# Altium schematic sheet IoT_Greenhouse V1.0 A - Outputs.SchDoc("IoT_Greenhouse V1.0 A - Outputs")
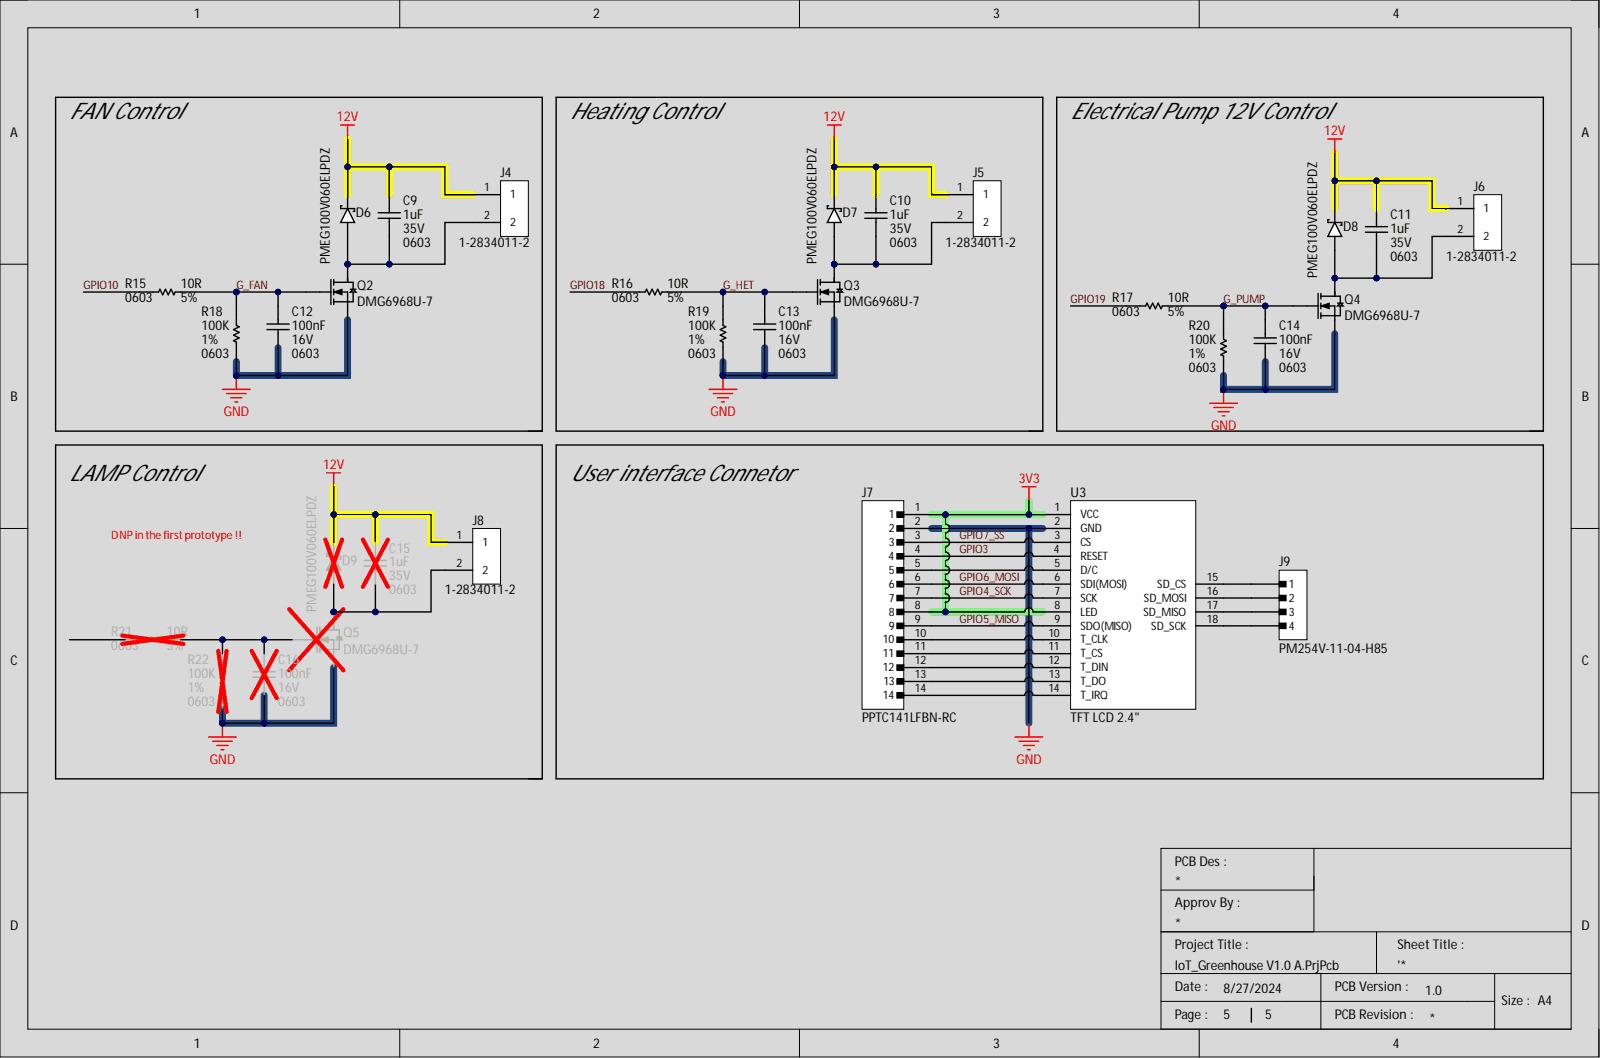
[diagram p0: IoT_Greenhouse V1.0 A - Outputs.SchDoc]

## Parts
C9  Capacitance: 1uF  Case Code (Imperial): 0603  Voltage Rating: 35V  [CAP_0603_CAPC1608X08N]  (hidden comment: GMK107BJ105KA-T)
C10  Capacitance: 1uF  Case Code (Imperial): 0603  Voltage Rating: 35V  [CAP_0603_CAPC1608X08N]  (hidden comment: GMK107BJ105KA-T)
C11  Capacitance: 1uF  Case Code (Imperial): 0603  Voltage Rating: 35V  [CAP_0603_CAPC1608X08N]  (hidden comment: GMK107BJ105KA-T)
C12  Capacitance: 100nF  Case Code (Imperial): 0603  Voltage: 16V  [CAP_0603_CAPC1608X08N]  (hidden comment: CL10B104KO8NNNC)
C13  Capacitance: 100nF  Case Code (Imperial): 0603  Voltage: 16V  [CAP_0603_CAPC1608X08N]  (hidden comment: CL10B104KO8NNNC)
C14  Capacitance: 100nF  Case Code (Imperial): 0603  Voltage: 16V  [CAP_0603_CAPC1608X08N]  (hidden comment: CL10B104KO8NNNC)
C15  Capacitance: 1uF  Case Code (Imperial): 0603  Voltage Rating: 35V  [CAP_0603_CAPC1608X08N]  (hidden comment: GMK107BJ105KA-T)
C16  Capacitance: 100nF  Case Code (Imperial): 0603  Voltage: 16V  [CAP_0603_CAPC1608X08N]  (hidden comment: CL10B104KO8NNNC)
D6  PMEG100V060ELPDZ  [DIO_SCKTY_PMEG060V100EPDAZ]
D7  PMEG100V060ELPDZ  [DIO_SCKTY_PMEG060V100EPDAZ]
D8  PMEG100V060ELPDZ  [DIO_SCKTY_PMEG060V100EPDAZ]
D9  PMEG100V060ELPDZ  [DIO_SCKTY_PMEG060V100EPDAZ]
J4  1-2834011-2  [CONN_TBLOCK_128340112]
J5  1-2834011-2  [CONN_TBLOCK_128340112]
J6  1-2834011-2  [CONN_TBLOCK_128340112]
J7  PPTC141LFBN-RC  [CONN_HEADER_RHDR14W80P0X254_1X14_3606X254X850P]
J8  1-2834011-2  [CONN_TBLOCK_128340112]
J9  PM254V-11-04-H85  [CONN_HEADER_FEM_4P]
Q2  DMG6968U-7  [SOT_23_3_MMBT2907A-13]
Q3  DMG6968U-7  [SOT_23_3_MMBT2907A-13]
Q4  DMG6968U-7  [SOT_23_3_MMBT2907A-13]
Q5  DMG6968U-7  [SOT_23_3_MMBT2907A-13]
R15  Case Code (Imperial): 0603  Resistance: 10R  Resistance Tolerance: 5%  [RES_0603_RESC1608X04N]  (hidden comment: RC0603JR-0710RL)
R16  Case Code (Imperial): 0603  Resistance: 10R  Resistance Tolerance: 5%  [RES_0603_RESC1608X04N]  (hidden comment: RC0603JR-0710RL)
R17  Case Code (Imperial): 0603  Resistance: 10R  Resistance Tolerance: 5%  [RES_0603_RESC1608X04N]  (hidden comment: RC0603JR-0710RL)
R18  Case Code (Imperial): 0603  Resistance: 100K  Resistance Tolerance: 1%  [RES_0603_RESC1608X04N]  (hidden comment: RC0603FR-07100KL)
R19  Case Code (Imperial): 0603  Resistance: 100K  Resistance Tolerance: 1%  [RES_0603_RESC1608X04N]  (hidden comment: RC0603FR-07100KL)
R20  Case Code (Imperial): 0603  Resistance: 100K  Resistance Tolerance: 1%  [RES_0603_RESC1608X04N]  (hidden comment: RC0603FR-07100KL)
R21  Case Code (Imperial): 0603  Resistance: 10R  Resistance Tolerance: 5%  [RES_0603_RESC1608X04N]  (hidden comment: RC0603JR-0710RL)
R22  Case Code (Imperial): 0603  Resistance: 100K  Resistance Tolerance: 1%  [RES_0603_RESC1608X04N]  (hidden comment: RC0603FR-07100KL)

## Nets
3V3: J7.1 J7.8
12V: C9.2 C10.2 C11.2 C15.2 D6.K D7.K D8.K D9.K J4.1 J5.1 J6.1 J8.1
GND: C12.1 C13.1 C14.1 C16.1 J7.2 Q2.2 Q3.2 Q4.2 Q5.2 R18.1 R19.1 R20.1 R22.1
G_FAN: C12.2 Q2.1 R15.2 R18.2
G_HET: C13.2 Q3.1 R16.2 R19.2
G_PUMP: C14.2 Q4.1 R17.2 R20.2
GPIO3: J7.4
GPIO4_SCK: J7.7
GPIO5_MISO: J7.9
GPIO6_MOSI: J7.6
GPIO7_SS: J7.3
GPIO10: R15.1
GPIO18: R16.1
GPIO19: R17.1
NetC9_1: C9.1 D6.A J4.2 Q2.3
NetC10_1: C10.1 D7.A J5.2 Q3.3
NetC11_1: C11.1 D8.A J6.2 Q4.3
NetC15_1: C15.1 D9.A J8.2 Q5.3
NetC16_2: C16.2 Q5.1 R21.2 R22.2

Not connected: J7 5 10 11 12 13 14; J9 1 2 3 4; R21 1

## Library data of the parts
C9-C11 C15 = CAP_1uF_35V_X5R_0603: Multilayer Ceramic Capacitors (High dielectric type), 35V, 1 uF, ± 10 %, 0603, X5R, RoHS; Manufacturer: Taiyo Yuden; Dielectric Material: 4241ConductorMaterial; Tolerance: 10%; Case Code (Metric): 1608; Case/Package: 0603; Category: Ceramic Capacitors; Component Kind: Standard; ComponentLink1Description: https://datasheet.ciiva.com/134/gmk107bj105ka-t-ss-134746.pdf?src-supplier=Digikey; ComponentLink1URL: https://datasheet.ciiva.com/134/gmk107bj105ka-t-ss-134746.pdf?src-supplier=Digikey; ComponentLink2Description: https://datasheet.ciiva.com/30065/198gmk107bj105ka-t-30065053.pdf?src-supplier=Onlinecomponents.com; ComponentLink2URL: https://datasheet.ciiva.com/30065/198gmk107bj105ka-t-30065053.pdf?src-supplier=Onlinecomponents.com; ComponentLink3Description: https://datasheet.ciiva.com/11463/gmk107bj105ka-t-ss-11463824.pdf?src-supplier=Avnet; ComponentLink3URL: https://datasheet.ciiva.com/11463/gmk107bj105ka-t-ss-11463824.pdf?src-supplier=Avnet; ComponentLink4Description: https://datasheet.ciiva.com/15920/1014gmk107bj105ka-t-15920678.pdf?src-supplier=Onlinecomponents.com; ComponentLink4URL: https://datasheet.ciiva.com/15920/1014gmk107bj105ka-t-15920678.pdf?src-supplier=Onlinecomponents.com; ComponentLink5Description: https://datasheet.ciiva.com/26871/getdatasheetpartid-637436-26871549.pdf?src-supplier=Verical; ComponentLink5URL: https://datasheet.ciiva.com/26871/getdatasheetpartid-637436-26871549.pdf?src-supplier=Verical; ComponentLink6Description: https://datasheet.ciiva.com/30181/9gmk107bj105ka-t-30181659.pdf?src-supplier=Onlinecomponents.com; ComponentLink6URL: https://datasheet.ciiva.com/30181/9gmk107bj105ka-t-30181659.pdf?src-supplier=Onlinecomponents.com; ComponentLink7Description: https://datasheet.ciiva.com/5415/mlcc-all-e-541559-5415038.pdf?src-supplier=Mouser; ComponentLink7URL: https://datasheet.ciiva.com/5415/mlcc-all-e-541559-5415038.pdf?src-supplier=Mouser; Construction: Flat; Depth: 0.8mm; Dielectric: X5R; Halogen Free: 5694HalogenFree; Height: 0.8mm; Lead Free: 5697LeadFree; Length: 1.6mm; Library Name: Electronic Devices_LIB.SchLib; Manufacturer Part Number: GMK107BJ105KA-T; Material: 4241ConductorMaterial; Max Operating Temperature: 85°C; Min Operating Temperature: -55°C; Mount: Surface Mount; Package Quantity: 4000; Packaging: Tape and Reel; Pricing 1: 1=0.17, 10=0.108, 50=0.0682, 100=0.0545, 500=0.0394, 1000=0.03484 (USD); Radiation Hardening: No; Reach SVHC Compliant: No; RoHS: Yes; RoHS Compliant: Yes; Series: M; Stock 1: 1326938; Supplier 1: Digikey; Supplier Part Number 1: 587-1437-6-ND; Termination: SMD/SMT; Voltage Rating (DC): 35V; Width: 0.8mm
C12-C14 C16 = CAP_100NF_16V_X7R_0603: Ceramic capacitor SMD-0603 100nF /16V X7R# K +-10%, Samsung, RoHS; Dielectric: X7R; Tolerance: 10%; Case Code (Metric): 1608; Case/Package: 0603; Category: Ceramic Capacitors; Component Kind: Standard; ComponentLink1Description: https://datasheet.ciiva.com/25805/cl10b104ko8nnnc-25805483.pdf?src-supplier=Digi-Key; ComponentLink1URL: https://datasheet.ciiva.com/25805/cl10b104ko8nnnc-25805483.pdf?src-supplier=Digi-Key; ComponentLink2Description: https://datasheet.ciiva.com/26838/getdatasheetpartid-357417-26838418.pdf?src-supplier=Verical; ComponentLink2URL: https://datasheet.ciiva.com/26838/getdatasheetpartid-357417-26838418.pdf?src-supplier=Verical; ComponentLink3Description: https://datasheet.ciiva.com/29198/456679-da-01-en-vs-kondensator-0603-29198846.pdf?src-supplier=Conrad; ComponentLink3URL: https://datasheet.ciiva.com/29198/456679-da-01-en-vs-kondensator-0603-29198846.pdf?src-supplier=Conrad; Contact Plating: Tin; Depth: 0.8mm; Height: 0.8mm; Lead Free: Lead Free; Length: 1.6mm; Library Name: Electronic Devices_LIB.SchLib; Manufacturer: Samsung; Manufacturer Part Number: CL10B104KO8NNNC; Material: Ceramic; Max Operating Temperature: 125°C; Min Operating Temperature: -55°C; Mount: Surface Mount; Package Quantity: 1; Packaging: Tape and Reel; Pricing 1: 1=0.10000, 10=0.02700, 50=0.01500, 100=0.01220, 500=0.00874, 1000=0.00686 (USD); Radiation Hardening: No; RoHS: Yes; RoHS Compliant: Yes; Series: CL; Stock 1: 9799745; Supplier 1: Digi-Key; Supplier 2: Newark; Supplier 3: Mouser; Supplier Part Number 1: 1276-1005-1-ND; Supplier Part Number 2: 82AC9325; Supplier Part Number 3: 187-CL10B104KO8NNNC; Thickness: 0.035inch; Voltage Rating: 16V; Width: 0.031inch
D6-D9 = DIO_SCTKY_100V_6A_PMEG100V060ELPDZ: DIODESCHOTTKY100V6ACFP15; Manufacturer: Nexperia; Category: Schottky Diodes; Component Kind: Standard; ComponentLink1Description: http://www.farnell.com/datasheets/2341403.pdf?src-supplier=Farnell; ComponentLink1URL: http://www.farnell.com/datasheets/2341403.pdf?src-supplier=Farnell; ComponentLink2Description: https://datasheet.octopart.com/PMEG100V060ELPDZ-Nexperia-datasheet-87796136.pdf?src-supplier=Future+Electronics; ComponentLink2URL: https://datasheet.octopart.com/PMEG100V060ELPDZ-Nexperia-datasheet-87796136.pdf?src-supplier=Future+Electronics; Library Name: Electronic Devices_LIB.SchLib; Manufacturer Part Number: PMEG100V060ELPDZ; Pricing 1: 1=0.58, 10=0.504, 100=0.3491, 500=0.29166 (USD); RoHS: Yes; RoHS Compliant: Yes; Stock 1: 60422; Supplier 1: Digikey
J4-J6 J8 = CONN_TBLOCK_2P_3.5mm_1-2834011-2: 2POS3.5MMTOPENTRYSCREWLESSC; Manufacturer: TE Connectivity; Approvals: UL; Connector Type: Receptacle,Connector; Contact Current Rating: 6A; Number of Levels: 1; Number of Positions: 2; Sealable: No (Without); Voltage: 300V; Wire Gauge (Max): 20AWG; Wire Gauge (Min): 14AWG; Category: Terminal Blocks; Color: Green; Component Kind: Standard; ComponentLink1Description: http://www.te.com/commerce/DocumentDelivery/DDEController?Action=srchrtrv&DocNm=1-1773872-7_ModularScrewlessTerminalBloc&DocType=DS&DocLang=English&src-supplier=Digikey; ComponentLink1URL: http://www.te.com/commerce/DocumentDelivery/DDEController?Action=srchrtrv&DocNm=1-1773872-7_ModularScrewlessTerminalBloc&DocType=DS&DocLang=English&src-supplier=Digikey; ComponentLink2Description: https://datasheet.octopart.com/1-2834011-2-TE-Connectivity-datasheet-62069794.pdf?src-supplier=Farnell; ComponentLink2URL: https://datasheet.octopart.com/1-2834011-2-TE-Connectivity-datasheet-62069794.pdf?src-supplier=Farnell; ComponentLink3Description: http://www.farnell.com/datasheets/2296738.pdf?src-supplier=Newark; ComponentLink3URL: http://www.farnell.com/datasheets/2296738.pdf?src-supplier=Newark; ComponentLink4Description: https://datasheet.octopart.com/1-2834011-2-TE-Connectivity-datasheet-77874288.pdf?src-supplier=Element14; ComponentLink4URL: https://datasheet.octopart.com/1-2834011-2-TE-Connectivity-datasheet-77874288.pdf?src-supplier=Element14; Contact Plating: Tin,Lead; Current: 6A; Current Rating: 6A; Housing Material: Nylon; Lead Free: Lead Free; Library Name: Electronic Devices_LIB.SchLib; Manufacturer Part Number: 1-2834011-2; Max Operating Temperature: 105°C; Min Operating Temperature: -40°C; Mount: Through Hole; Number of Pins: 2; Number of Positions Per Level: 2; Packaging: Box; Pricing 1: 1=0.25, 10=0.209, 25=0.194, 50=0.1832, 100=0.1732, 250=0.16068, 500=0.15182, 1000=0.14552 (USD); Reach SVHC Compliant: Unknown; RoHS: Yes; RoHS Compliant: Yes; Series: -; Stock 1: 110419; Supplier 1: Digikey; Supplier Part Number 1: A123848-ND; Termination: Through Hole; Voltage Rating: 300V; Wire/Cable Gauge: 14AWG
J7 = CONN_HEADER_FEMALE_14P_2.54mm: PPTC Series 14 Position 2.54 mm Single Row Through Hole Pin Header; Manufacturer: Sullins; Number of Positions: 14; Voltage Rating: 250V; Category: Connectors; Component Kind: Standard; ComponentLink1Description: https://media.digikey.com/pdf/Data%20Sheets/Sullins%20PDFs/Female_Headers.100_DS.pdf?src-supplier=Digikey; ComponentLink1URL: https://media.digikey.com/pdf/Data%20Sheets/Sullins%20PDFs/Female_Headers.100_DS.pdf?src-supplier=Digikey; Connector Type: Header; Contact Finish - Mating: TIN; Contact Material: Bronze; Contact Shape: Square; Contact Type: Female Socket; Fastening Type: Pull; Flammability Rating: UL94 V-0; Height: 8.5mm; Housing Material: Plastic; Insulation Color: Black; Insulation Material: Polyester; Lead Free: Lead Free; Length: 36.1mm; Library Name: Electronic Devices_LIB.SchLib; Manufacturer Part Number: PPTC141LFBN-RC; Max Operating Temperature: 105°C; Min Operating Temperature: -40°C; Mount: Through Hole; Number of Rows: 1; Packaging: Tray; Pricing 1: 1=0.92, 10=0.78, 80=0.70075, 440=0.5733, 1000=0.5096, 5000=0.455 (USD); RoHS: Yes; RoHS Compliant: Yes; Series: -; Stock 1: 3902; Supplier 1: Digikey; Supplier Part Number 1: S7012-ND; Termination: Solder; Width: 2.5mm
J9 = CONN_HEADER_FEMALE_4P_2.54mm_JLCPCB: 2.54mm Plugin 4P Brass Straight 1x4P Plugin,P=2.54mm  Female Headers ROHS; Manufacturer: XFCN; Connector Type: Header; Number of Positions: 4; Voltage Rating: 250V; Category: Connectors; Component Kind: Standard; Contact Finish - Mating: TIN; Contact Material: Bronze; Contact Shape: Square; Contact Type: Female Socket; Fastening Type: Pull; Flammability Rating: UL94 V-0; Height: 8.5mm; Housing Material: Plastic; Insulation Color: Black; Insulation Material: Polyester; JLCPCB Part Number: C541851; Lead Free: Lead Free; Length: 10.7mm; Library Name: Electronic Devices_LIB.SchLib; Manufacturer Part Number: PM254V-11-04-H85; Max Operating Temperature: 105°C; Min Operating Temperature: -40°C; Mount: Through Hole; Number of Rows: 1; Packaging: Tray; Pitch: 2.54mm; RoHS: Yes; RoHS Compliant: Yes; Series: -; Supplier: Jlcpcb; Termination: Solder; Width: 2.5mm
Q2-Q5 = TRANS_FET_CH_N_20V_6.5A_DMG6968U-7: Trans Mosfet N-ch 20V 6.5A 3-PIN SOT-23 T/r Trans Mosfet N-ch 20V 6.5A 3-PIN SOT-23 T/r; Manufacturer: Diodes; Drain to Source Resistance: 25mR; Drain-source On Resistance-Max: 36mR; Fall Time: 205ns; Gate to Source Voltage (Vgs): 12V; Input Capacitance: 151pF; Max Power Dissipation: 1.3W; Nominal Vgs: 500mV; Number of Channels: 1; Polarity: N-CHANNEL; Rise Time: 66ns; Turn-Off Delay Time: 613ns; Turn-On Delay Time: 54ns; Case/Package: SOT-23-3; Category: MOSFETs; Component Kind: Standard; ComponentLink1Description: https://datasheet.ciiva.com/5774/ds31738-5774992.pdf?src-supplier=Farnell; ComponentLink1URL: https://datasheet.ciiva.com/5774/ds31738-5774992.pdf?src-supplier=Farnell; ComponentLink2Description: https://datasheet.ciiva.com/22712/1915638-22712395.pdf?src-supplier=Element14; ComponentLink2URL: https://datasheet.ciiva.com/22712/1915638-22712395.pdf?src-supplier=Element14; Continuous Drain Current (ID): 6.5A; Drain to Source Voltage (Vdss): 20V; Height: 1mm; Lead Free: Lead Free; Length: 2.9mm; Library Name: Electronic Devices_LIB.SchLib; Manufacturer Part Number: DMG6968U-7; Max Operating Temperature: 150°C; Min Operating Temperature: -55°C; Mount: Surface Mount; Number of Pins: 3; Package Quantity: 1; Packaging: Tape and Reel; Pricing 1: 1=0.37, 10=0.289, 100=0.1732, 500=0.16034, 1000=0.10903 (USD); Radiation Hardening: No; Rds On Max: 25mR; Reach SVHC Compliant: No; RoHS: Yes; RoHS Compliant: Yes; Series: -; Stock 1: 575891; Supplier 1: Digikey; Supplier Part Number 1: DMG6968UDICT-ND; Threshold Voltage: 500mV; Weight: 0.000282oz; Width: 1.3mm
R15-R17 R21 = RES_10R_5%_100mW_0603: SMD Chip Resistor, 10 Ohm, ± 5%, 100 mW, 0603 [1608 Metric], Thick Film, General Purpose; Manufacturer: Yageo; Max Power Dissipation: 100mW; Temperature Coefficient: 200ppm/°C; Voltage Rating: 50V; Case Code (Metric): 1608; Case/Package: 0603; Category: Chip SMD Resistors; Component Kind: Standard; ComponentLink1Description: https://datasheet.ciiva.com/46395/443971-46395069.pdf?src-supplier=Element14; ComponentLink1URL: https://datasheet.ciiva.com/46395/443971-46395069.pdf?src-supplier=Element14; ComponentLink2Description: https://datasheet.ciiva.com/7746/443971-7746431.pdf?src-supplier=Element14; ComponentLink2URL: https://datasheet.ciiva.com/7746/443971-7746431.pdf?src-supplier=Element14; Construction: Rectangular; Depth: 0.8mm; Features: Moisture Resistant; Lead Free: Lead Free; Length: 1.6mm; Library Name: Electronic Devices_LIB.SchLib; Manufacturer Part Number: RC0603JR-0710RL; Max Operating Temperature: 155°C; Military Standard: Not; Min Operating Temperature: -55°C; Number of Pins: 2; Number of Terminations: 2; Packaging: Tape and Reel; Power Rating: 100mW; Pricing 1: 1=0.1, 10=0.024, 50=0.0154, 100=0.013, 500=0.00894, 1000=0.00773 (USD); Reach SVHC Compliant: No; Resistor Type: Thick Film; RoHS: Yes; RoHS Compliant: Yes; Series: RC; Size Code: 0603; Stock 1: 769777; Supplier 1: Digi-Key; Supplier Part Number 1: 311-10GRCT-ND; Termination: SMD/SMT; Tolerance: 5%; Voltage Rating (DC): 50V; Width: 0.8mm
R18-R20 R22 = RES_100K_1%_100mW_0603: RES SMD 100K OHM 1% 1/10W 0603; Manufacturer: Yageo; Max Power Dissipation: 100mW; Temperature Coefficient: 100ppm/°C; Voltage Rating: 50V; Working Voltage: 75V; Case Code (Metric): 1608; Case/Package: 0603; Category: Chip SMD Resistors; Component Kind: Standard; ComponentLink1Description: https://datasheet.ciiva.com/11808/0900766b80f96399-11808107.pdf?src-supplier=Rs; ComponentLink1URL: https://datasheet.ciiva.com/11808/0900766b80f96399-11808107.pdf?src-supplier=Rs; ComponentLink2Description: https://datasheet.ciiva.com/7983/443971-7983593.pdf?src-supplier=Element14; ComponentLink2URL: https://datasheet.ciiva.com/7983/443971-7983593.pdf?src-supplier=Element14; ComponentLink3Description: https://datasheet.ciiva.com/22747/443971-22747516.pdf?src-supplier=Element14; ComponentLink3URL: https://datasheet.ciiva.com/22747/443971-22747516.pdf?src-supplier=Element14; ComponentLink4Description: https://datasheet.ciiva.com/46440/1868787-46440889.pdf?src-supplier=Element14; ComponentLink4URL: https://datasheet.ciiva.com/46440/1868787-46440889.pdf?src-supplier=Element14; ComponentLink5Description: https://datasheet.ciiva.com/7746/1738695-7746235.pdf?src-supplier=Element14; ComponentLink5URL: https://datasheet.ciiva.com/7746/1738695-7746235.pdf?src-supplier=Element14; ComponentLink6Description: https://datasheet.ciiva.com/7746/1738695-7746234.pdf?src-supplier=Element14; ComponentLink6URL: https://datasheet.ciiva.com/7746/1738695-7746234.pdf?src-supplier=Element14; Construction: Rectangular; Depth: 0.8mm; Features: Moisture Resistant; Height: 0.45mm; Lead Free: Lead Free; Length: 1.6mm; Library Name: Electronic Devices_LIB.SchLib; Manufacturer Part Number: RC0603FR-07100KL; Max Operating Temperature: 155°C; Military Standard: Not; Min Operating Temperature: -55°C; Number of Pins: 2; Number of Terminations: 2; Packaging: Tape and Reel; Power Rating: 100mW; Pricing 1: 1=0.10000, 10=0.01900, 100=0.00770, 1000=0.00345, 2500=0.00300 (USD); Reach SVHC Compliant: Yes; Resistor Type: Thick Film; RoHS: Yes; RoHS Compliant: Yes; Series: RC; Stock 1: 11038732; Supplier 1: Digi-Key; Supplier Part Number 1: 311-100KHRDKR-ND; Technology: THICK FILM; Termination: SMD/SMT; Tolerance: 1%; Voltage Rating (DC): 50V; Width: 0.8mm
